# Altium schematic sheet MainSheet.SchDoc
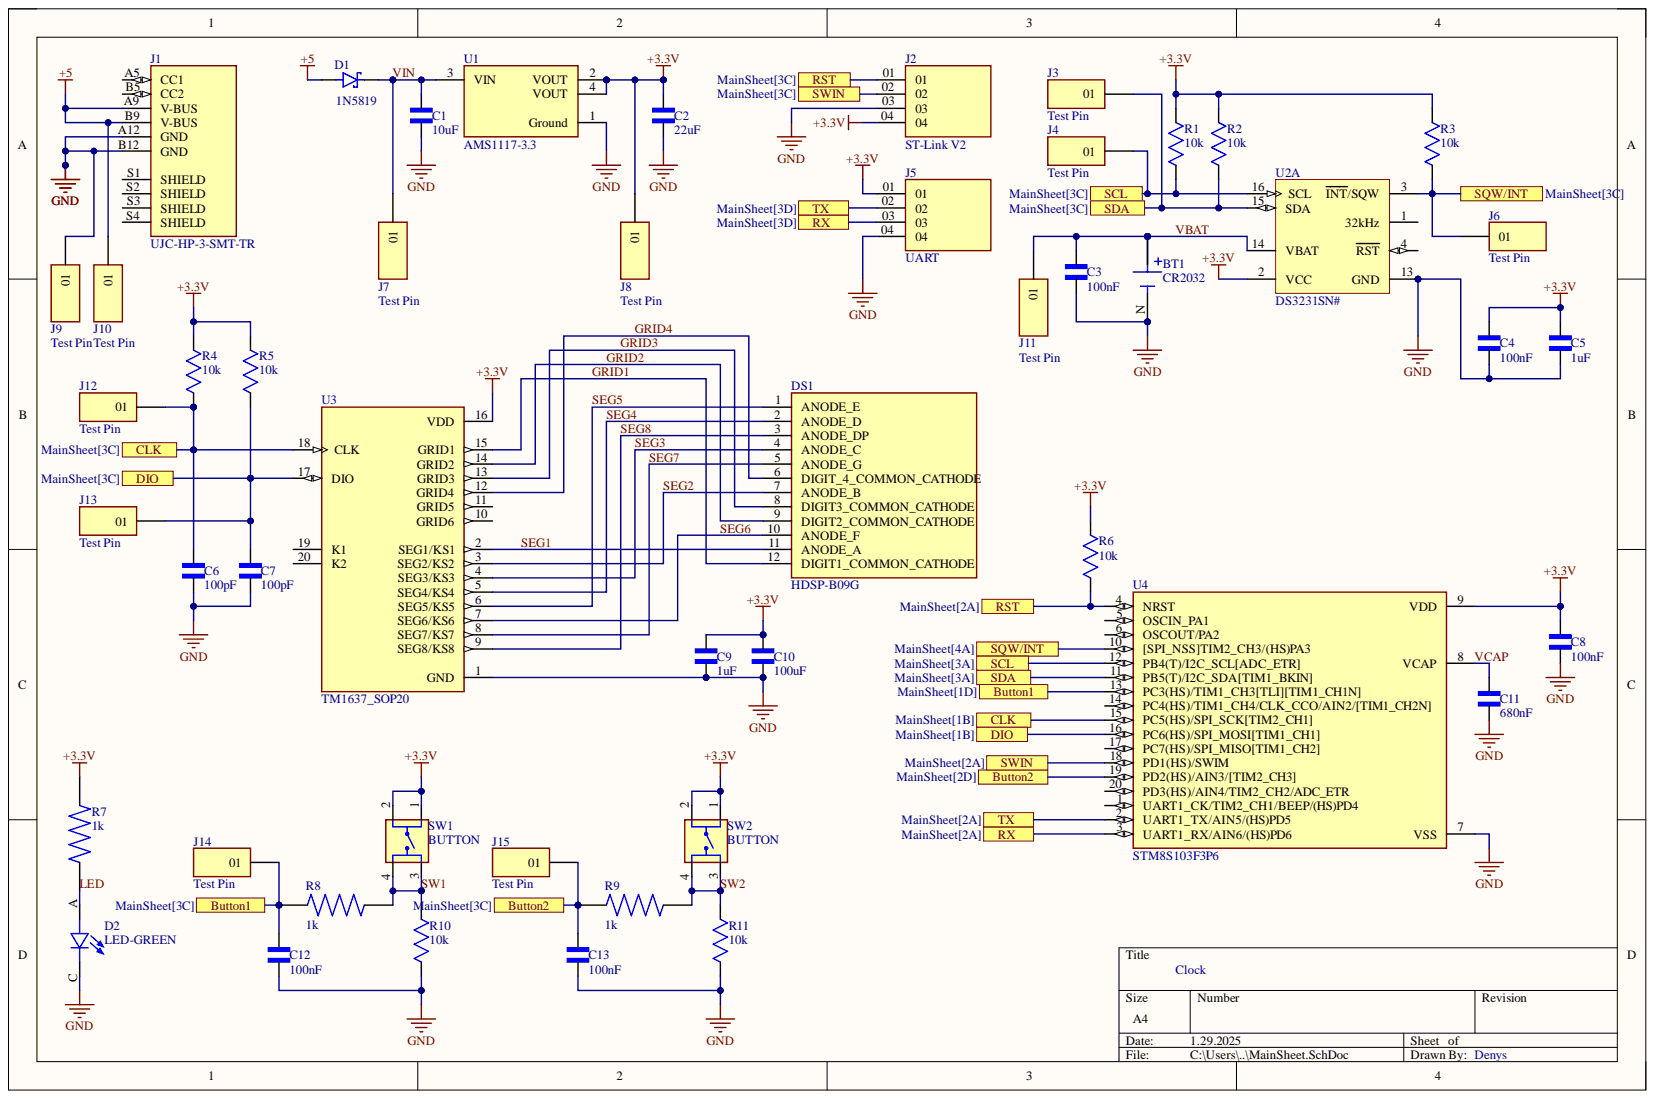
[diagram p0: MainSheet.SchDoc]

## Parts
BT1  CR2032  [BT_2898]
C1  10uF  [CAPC3216X190]
C2  22uF  [CAPC3216X125N]
C3  100nF  [CAPC3216X180N]
C4  100nF  [CAPC3216X180N]
C5  1uF  [CAPC3216X110N]
C6  100pF  [CAPC3216X110N]
C7  100pF  [CAPC3216X110N]
C8  100nF  [CAPC3216X180N]
C9  1uF  [CAPC3216X110N]
C10  100uF  [CAP3216X125N]
C11  680nF  [CAPC3216X110N]
C12  100nF  [CAPC3216X180N]
C13  100nF  [CAPC3216X180N]
D1  1N5819  [SOD3715X145N]
D2  LED-GREEN  [LED_LTST-C230KGKT]
DS1  HDSP-B09G  [AVAGO_HDSP-B09G]
J1  UJC-HP-3-SMT-TR  [CUI_UJC-HP-3-SMT-TR]
J2  ST-Link V2  [SAMTEC_TSW-104-07-S-S]
J3  Test Pin  [SAMTEC_MTMM-101-X-X-S-X]
J4  Test Pin  [SAMTEC_MTMM-101-X-X-S-X]
J5  UART  [SAMTEC_TSW-104-07-S-S]
J6  Test Pin  [SAMTEC_MTMM-101-X-X-S-X]
J7  Test Pin  [SAMTEC_MTMM-101-X-X-S-X]
J8  Test Pin  [SAMTEC_MTMM-101-X-X-S-X]
J9  Test Pin  [SAMTEC_MTMM-101-X-X-S-X]
J10  Test Pin  [SAMTEC_MTMM-101-X-X-S-X]
J11  Test Pin  [SAMTEC_MTMM-101-X-X-S-X]
J12  Test Pin  [SAMTEC_MTMM-101-X-X-S-X]
J13  Test Pin  [SAMTEC_MTMM-101-X-X-S-X]
J14  Test Pin  [SAMTEC_MTMM-101-X-X-S-X]
J15  Test Pin  [SAMTEC_MTMM-101-X-X-S-X]
R1  10k  [RESC3216X71N]
R2  10k  [RESC3216X71N]
R3  10k  [RESC3216X71N]
R4  10k  [RESC3216X71N]
R5  10k  [RESC3216X71N]
R6  10k  [RESC3216X71N]
R7  1k  [RESC3116X65N]
R8  1k  [RESC3116X65N]
R9  1k  [RESC3116X65N]
R10  10k  [RESC3216X71N]
R11  10k  [RESC3216X71N]
SW1  BUTTON  [TE_4-1437565-9]
SW2  BUTTON  [TE_4-1437565-9]
U1  AMS1117-3.3  [FP-AMS1117-IPC_A]
U2  DS3231SN#  [W16#H2_N]  (part A)
U3  TM1637_SOP20  [SOIC127P1035X255-20N]
U4  STM8S103F3P6  [SOP65P640X120-20N]

## Ports
Button1, Button2, CLK, DIO, RST, RX, SCL, SDA, SQW/INT, SWIN, TX

## Nets
+3.3V: C2.2 C4.1 C5.1 C8.1 C9.1 C10.1 J2.04 J5.01 J8.01 R1.1 R2.1 R3.1 R4.1 R5.1 R6.1 R7.2 SW1.1 SW1.2 SW2.1 SW2.2 U1.2(VOUT) U1.4(VOUT) U2.2(VCC) U3.16(VDD) U4.9(VDD)
+5: D1.A J1.A9(V-BUS) J1.B9(V-BUS) J10.01
GND: BT1.N C1.2 C2.1 C3.2 C4.2 C5.2 C6.2 C7.2 C8.2 C9.2 C10.2 C11.2 C12.2 C13.2 D2.C J1.A12(GND) J1.B12(GND) J2.03 J5.04 J9.01 R10.2 R11.2 U1.1(Ground) U2.13(GND) U3.1(GND) U4.7(VSS)
Button1: C12.1 J14.01 R8.2 U4.13(PC3(HS)/TIM1_CH3[TLI][TIM1_CH1N]) ; port Button1
Button2: C13.1 J15.01 R9.2 U4.19(PD2(HS)/AIN3/[TIM2_CH3]) ; port Button2
CLK: C6.1 J12.01 R4.2 U3.18(CLK) U4.15(PC5(HS)/SPI_SCK[TIM2_CH1]) ; port CLK
DIO: C7.1 J13.01 R5.2 U3.17(DIO) U4.16(PC6(HS)/SPI_MOSI[TIM1_CH1]) ; port DIO
GRID1: DS1.12(DIGIT1_COMMON_CATHODE) U3.15(GRID1)
GRID2: DS1.9(DIGIT2_COMMON_CATHODE) U3.14(GRID2)
GRID3: DS1.8(DIGIT3_COMMON_CATHODE) U3.13(GRID3)
GRID4: DS1.6 U3.12(GRID4)
LED: D2.A R7.1
RST: J2.01 R6.2 U4.4(NRST) ; port RST
RX: J5.03 U4.3(UART1_RX/AIN6/(HS)PD6) ; port RX
SCL: J4.01 R1.2 U2.16(SCL) U4.12(PB4(T)/I2C_SCL[ADC_ETR]) ; port SCL
SDA: J3.01 R2.2 U2.15(SDA) U4.11(PB5(T)/I2C_SDA[TIM1_BKIN]) ; port SDA
SEG1: DS1.11(ANODE_A) U3.2(SEG1/KS1)
SEG2: DS1.7(ANODE_B) U3.3(SEG2/KS2)
SEG3: DS1.4(ANODE_C) U3.4(SEG3/KS3)
SEG4: DS1.2(ANODE_D) U3.5(SEG4/KS4)
SEG5: DS1.1(ANODE_E) U3.6(SEG5/KS5)
SEG6: DS1.10(ANODE_F) U3.7(SEG6/KS6)
SEG7: DS1.5(ANODE_G) U3.8(SEG7/KS7)
SEG8: DS1.3(ANODE_DP) U3.9(SEG8/KS8)
SQW/INT: J6.01 R3.2 U2.3(INT/SQW) U4.10([SPI_NSS]TIM2_CH3/(HS)PA3) ; port SQW/INT
SW1: R8.1 R10.1 SW1.3 SW1.4
SW2: R9.1 R11.1 SW2.3 SW2.4
SWIN: J2.02 U4.18(PD1(HS)/SWIM) ; port SWIN
TX: J5.02 U4.2(UART1_TX/AIN5/(HS)PD5) ; port TX
VBAT: BT1.P1 BT1.P2 C3.1 J11.01 U2.14(VBAT)
VCAP: C11.1 U4.8(VCAP)
VIN: C1.1 D1.C J7.01 U1.3(VIN)

Not connected: J1 A5(CC1) B5(CC2) S1(SHIELD) S2(SHIELD) S3(SHIELD) S4(SHIELD); U2 1(32kHz) 4(RST); U3 10(GRID6) 11(GRID5) 19(K1) 20(K2); U4 1(UART1_CK/TIM2_CH1/BEEP/(HS)PD4) 5(OSCIN_PA1) 6(OSCOUT/PA2) 14(PC4(HS)/TIM1_CH4/CLK_CCO/AIN2/[TIM1_CH2N]) 17(PC7(HS)/SPI_MISO[TIM1_CH2]) 20(PD3(HS)/AIN4/TIM2_CH2/ADC_ETR)

## Library data of the parts
BT1 = 2898: Availability: Unavailable; Component Kind: Standard; Library Name: 2898.IntLib; MP: 2898; Package: None; Price: None
C1 = GRM31CB31E106KA75L: Cap Ceramic 10uF 25V B 10% Pad SMD 1206 85Â°C T/R; Availability: Unavailable; Component Kind: Standard; Library Name: GRM31CB31E106KA75L.IntLib; MP: GRM31CB31E106KA75L; Package: None; Price: None
C2 = GRM31CR61E226KE15L: CAPACITOR, 1206, X5R, 25V, 22UF; Dielectric Characteristic:X5R; Capacitance:22µF; Capacitance Tolerance:± 10%; Voltage Rating:25V; Series:GRM Hi-Cap; Capacitor Case Style:1206; No. of Pins:2; Capacitor Mounting:SMD; SVHC:No SVHC ;RoHS Compliant: Yes; MP: altium_native_batch; Availability: Unavailable; Component Kind: Standard; Library Name: GRM31CR61E226KE15L.IntLib; Package: None; Price: None
C3 C4 C8 C12 C13 = CL31B104KBCNNNC: Ceramic capacitor SMD 1206 100 nF 50 V 10 % Samsung Electro-Mechanics CL31B104KBCNNNC 4000 pc(s); Availability: In Stock; Check_prices: https://www.snapeda.com/parts/CL31B104KBCNNNC/Samsung+Electro-Mechanics/view-part/?ref=eda; Component Kind: Standard; Library Name: CL31B104KBCNNNC.IntLib; MF: Samsung Electro-Mechanics; MP: CL31B104KBCNNNC; Package: 1206 Samsung; Price: None; Purchase-URL: https://pricing.snapeda.com/search/part/CL31B104KBCNNNC/?ref=eda; SnapEDA_Link: https://www.snapeda.com/parts/CL31B104KBCNNNC/Samsung+Electro-Mechanics/view-part/?ref=snap
C5 C9 = C1206C105J3RACTU: CAP CER 1UF 25V X7R 1206; Availability: Unavailable; Component Kind: Standard; Library Name: C1206C105J3RACTU.IntLib; MP: C1206C105J3RACTU; Package: None; Price: None
C6 C7 = C1206C101JBGACTU: CAP, MLCC, C0G, 100PF, 630V, 1206; Availability: Unavailable; Component Kind: Standard; Library Name: C1206C101JBGACTU.IntLib; MP: C1206C101JBGACTU; Package: None; Price: None
C10 = GRM31CR60J107KE39L: 100ÂµF Â±10% 6.3V Ceramic Capacitor X5R 1206 _3216 Metric_; MF: Murata Electronics; Component Kind: Standard; Digi-Key_Part_Number: 490-13982-1-ND; Digi-Key_Purchase_URL: https://www.digikey.com/product-detail/en/murata-electronics/GRM31CR60J107KE39L/490-13982-1-ND/6155812?utm_source=snapeda&utm_medium=aggregator&utm_campaign=symbol; Library Name: GRM31CR60J107KE39L.IntLib; MP: GRM31CR60J107KE39L; Package: 1206 Murata; SnapEDA_Link: https://www.snapeda.com/parts/GRM31CR60J107KE39L/Murata%20Electronics%20North%20America/view-part/1756509/?ref=snap
C11 = C1206C684K5RACTU: 680nF 50V 10% 1206; Availability: Unavailable; Component Kind: Standard; Library Name: C1206C684K5RACTU.IntLib; MP: C1206C684K5RACTU; Package: None; Price: None
D1 = 1N5819HW-7: Diode Schottky 40 V 1A Surface Mount SOD-123; MF: Diodes Inc.; Availability: In Stock; Check_prices: https://www.snapeda.com/parts/1N5819HW-7/Diodes+Inc./view-part/?ref=eda; Component Kind: Standard; Library Name: 1N5819HW-7.IntLib; MP: 1N5819HW-7; Package: SOD-123 Fairchild Semiconductor; Price: None; Purchase-URL: https://pricing.snapeda.com/search/part/1N5819HW-7/?ref=eda; SnapEDA_Link: https://www.snapeda.com/parts/1N5819HW-7/Diodes+Inc./view-part/?ref=snap
D2 = LTST-C230KGKT: Green 571nm LED Indication - Discrete 2V 1206 (3216 Metric); MF: Lite-On Inc.; Availability: In Stock; Check_prices: https://www.snapeda.com/parts/LTST-C230KGKT/Lite-On+Inc./view-part/?ref=eda; Component Kind: Standard; Library Name: LTST-C230KGKT.IntLib; MP: LTST-C230KGKT; Package: 1206 Lite-On; Price: None; Purchase-URL: https://pricing.snapeda.com/search?q=LTST-C230KGKT&ref=eda; SnapEDA_Link: https://www.snapeda.com/parts/LTST-C230KGKT/Lite-On+Inc./view-part/?ref=snap
DS1 = HDSP-B09G: Display Modules - LED Character and Numeric Green 7-Segment Clock 4 Character Common Cathode 2.2V 20mA 0.752 H x 2.016 W x 0.315 D _19.10mm x 51.20mm x 8.00mm_ 12-DIP _0.600, 15.24mm_; MF: Broadcom Limited; Availability: Unavailable; Component Kind: Standard; Library Name: HDSP-B09G.IntLib; MP: HDSP-B09G; Package: DIP-12 Broadcom; Price: None; SnapEDA_Link: https://www.snapeda.com/parts/HDSP-B09G/Broadcom%20Limited/view-part/1797524/?ref=snap
J1 = UJC-HP-3-SMT-TR: Type C, 3 A, Right Angle, Surface Mount (SMT), Power-Only USB Receptacle; Availability: Unavailable; Component Kind: Standard; Library Name: UJC-HP-3-SMT-TR.IntLib; MP: UJC-HP-3-SMT-TR; Package: None; Price: None
J2 J5 = TSW-104-07-S-S: MF: Samtec Inc.; Availability: Unavailable; Component Kind: Standard; Library Name: TSW-104-07-S-S.IntLib; MP: TSW-104-07-S-S; Package: None; Price: None
J3 J4 J6-J15 = MTMM-101-X-X-S-X: Connector Header Through Hole 1 position; MF: Samtec; MP: MTMM-101-05-G-S-225; Check_prices: https://www.snapeda.com/parts/MTMM-101-05-G-S-225/Samtec/view-part/?ref=eda; Component Kind: Standard; Library Name: MTMM-101-X-X-S-X.IntLib; Package: None; SnapEDA_Link: https://www.snapeda.com/parts/MTMM-101-05-G-S-225/Samtec/view-part/?ref=snap
R1-R6 R10 R11 = RC1206FR-0710KL: RES, 10K, 1%, 0.25W, 1206, THICK FILM; Resistor Case Style:1206 [3216 Metric]; Resistance:10kohm; Product Range:RC Series; Voltage Rating:200V; Resistor Element Material:Thick Film; Power Rating:250mW; Resistance Tolerance:Â± 1%; Temperature Coefficient:Â± 100ppm/Â°C; Automotive Qualification Standard:-; SVHC:No SVHC (15-Jan-2019); MF: Yageo Corporation; MP: RC1206FR0710KL; Check_prices: https://www.snapeda.com/parts/RC1206FR0710KL/Yageo/view-part/?ref=eda; Component Kind: Standard; Library Name: RC1206FR-0710KL.IntLib; Package: 1206-2 YAGEO; SnapEDA_Link: https://www.snapeda.com/parts/RC1206FR0710KL/Yageo/view-part/?ref=snap
R7-R9 = SR1206FR-071KL: Surge Chip Resistor Automotive Grade Thick Film 1206 1kOhm 1% Paper T/R; MF: Yageo Corporation; MP: SR1206FR071KL; Check_prices: https://www.snapeda.com/parts/SR1206FR071KL/Yageo/view-part/?ref=eda; Component Kind: Standard; Library Name: SR1206FR-071KL.IntLib; Package: 1206-2 YAGEO; SnapEDA_Link: https://www.snapeda.com/parts/SR1206FR071KL/Yageo/view-part/?ref=snap
SW1 SW2 = 4-1437565-9: Alcoswitch, Switch, Tactile, 50 mA, SPST, Pushbutton, Off[On], FSM6JSMA | TE Connectivity FSM6JSMA; Configuration_Pole-Throw: Single Pole - Single Throw; Contact_Current_Rating: 50 mA; Check_prices: https://www.snapeda.com/parts/FSM6JSMA/TE+Connectivity+ALCOSWITCH+Switches/view-part/?ref=eda; Component Kind: Standard; EU_RoHS_Compliance: Compliant; Library Name: 4-1437565-9.IntLib; MF: TE Connectivity; MP: FSM6JSMA; Package: None; SnapEDA_Link: https://www.snapeda.com/parts/FSM6JSMA/TE+Connectivity+ALCOSWITCH+Switches/view-part/?ref=snap
U1 = CMP-209535-000001-1: LDO Voltage Regulators 1A, 3.3V; Manufacturer: Advanced Monolithic Systems; Dropout Voltage: 1.1V; Max Junction Temp: +125°C; Max Power Dissipation: 1.2W; Max Supply Voltage: 15V; Nominal Supply Current: 5mA; Number Of Outputs: 1; Output Type: Fixed; Standoff Height: 0.64mm; Automotive: No; Category: IC; Component Kind: Standard; ComponentLink2Description: Datasheet; ComponentLink2URL: http://www.advanced-monolithic.com/pdf/ds1117.pdf; Device Class L1: Integrated Circuits (ICs); Device Class L2: Power Management ICs; Device Class L3: Voltage Regulators - Linear; Height: 1.8mm; Ipc Land Pattern Name: SOT229P700X180-4; Lead Free: Yes; Library Name: AMS1117-3.3.IntLib; Manufacturer Part Number: AMS1117-3.3; Octopart: https://octopart.com/ams1117-3.3-advanced+monolithic+systems-25899300; Output Current: 1A; Output Voltage: 3.3V; Package: AMS1117; RoHS: TRUE; Temperature: -40°C to +125°C; Temperature Range High: +125°C; Temperature Range Low: -40°C
U2 = CMP-0254-00293-2: Extremely Accurate I2C-Integrated Real-Time Clock/TCXO/Crystal, 16-Pin SO, -40 to 85 degC, RoHS Qualified; Code_IPC: SOIC127P1030-16; Code_JEDEC: MS-013-AA; Component Kind: Standard; ComponentLink1Description: Manufacturer URL; ComponentLink1URL: http://www.maxim-ic.com; ComponentLink2Description: Datasheet; ComponentLink2URL: http://datasheets.maxim-ic.com/en/ds/DS3231.pdf; ComponentLink3Description: Package Specification; ComponentLink3URL: http://pdfserv.maxim-ic.com/package_dwgs/21-0042.PDF; DatasheetVersion: Rev 8, Jul-2010; Library Name: DS3231SN.IntLib; PackageDescription: 16-Pin Small-Outline IC, Wide (0.3in), 1.27 mm Pitch, SOIC (W), RoHS Qualified; PackageReference: W16#H2; PackageVersion: revD, 2010
U3 = TM1637_SOP20: LED Drive Control Special Circuit; MF: Titan Micro Electronics; Check_prices: https://www.snapeda.com/parts/TM1637_SOP20/Titan+Micro+Electronics/view-part/?ref=eda; Component Kind: Standard; Library Name: TM1637_SOP20.IntLib; MP: TM1637_SOP20; Package: Package; SnapEDA_Link: https://www.snapeda.com/parts/TM1637_SOP20/Titan+Micro+Electronics/view-part/?ref=snap
U4 = STM8S103F3P6: STM8 series Microcontroller IC 8-Bit 16MHz 8KB (8K x 8) FLASH 20-TSSOP; MF: STMicroelectronics; Check_prices: https://www.snapeda.com/parts/STM8S103F3P6/STMicroelectronics/view-part/?ref=eda; Component Kind: Standard; Library Name: STM8S103F3P6.IntLib; MP: STM8S103F3P6; Package: TSSOP-20 STMicroelectronics; SnapEDA_Link: https://www.snapeda.com/parts/STM8S103F3P6/STMicroelectronics/view-part/?ref=snap
